# Altium schematic sheet Power Circuit.SchDoc
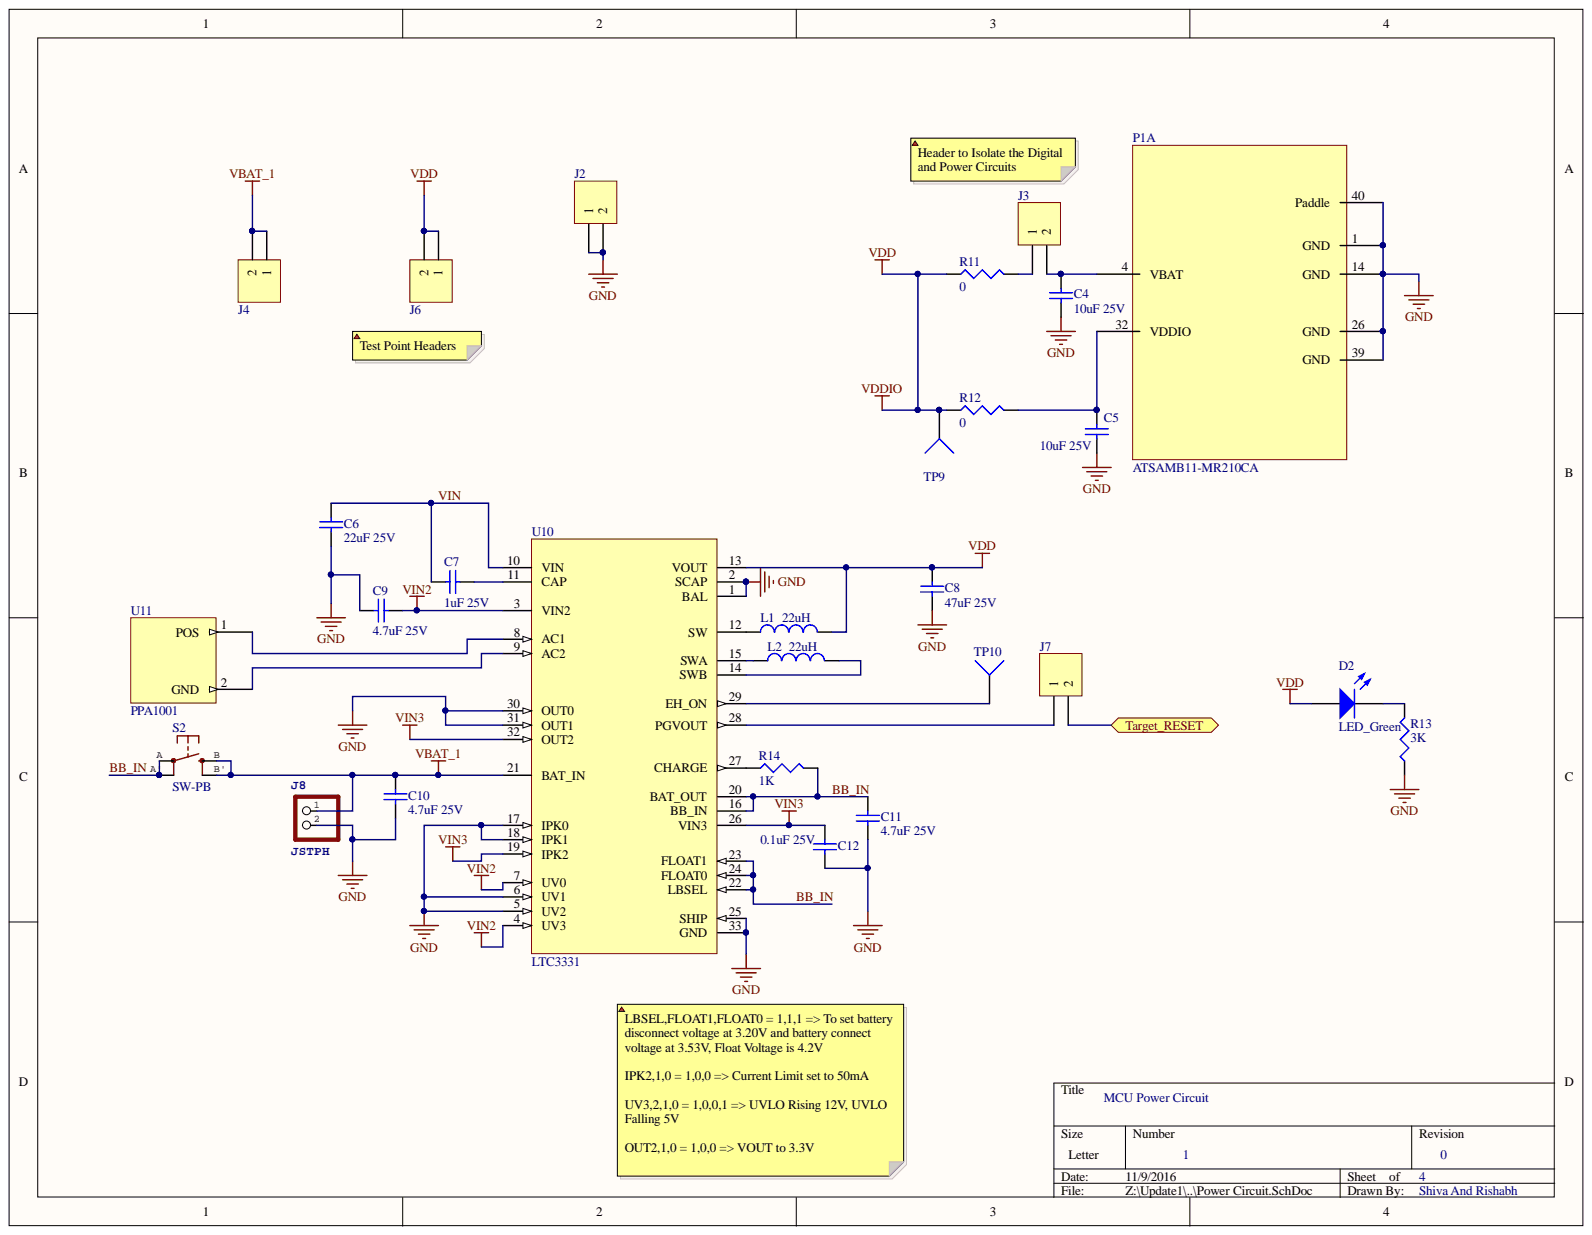
[diagram p0: Power Circuit.SchDoc]

## Parts
C4  value 10uF 25V  [Cap_1608[0603]]  (hidden comment: Cap10uF)
C5  value 10uF 25V  [Cap_1608[0603]]  (hidden comment: Cap10uF)
C6  value 22uF 25V  [Cap_1210]  (hidden comment: Cap22uF)
C7  value 1uF 25V  [Cap_1608[0603]]  (hidden comment: Cap1uF)
C8  value 47uF 25V  [Cap_1206]  (hidden comment: Cap47uF)
C9  value 4.7uF 25V  [Cap_1608[0603]]  (hidden comment: Cap4.7uF)
C10  value 4.7uF 25V  [Cap_1608[0603]]  (hidden comment: Cap4.7uF)
C11  value 4.7uF 25V  [Cap_1608[0603]]  (hidden comment: Cap4.7uF)
C12  value 0.1uF 25V  [Cap_1608[0603]]  (hidden comment: Cap0.1uF)
D2  LED_Green  [LED_0603]
J2  Header 2  [JMPR_HDR1X2]  (value not drawn)
J3  Header 2  [JMPR_HDR1X2]  (value not drawn)
J4  Header 2  [JMPR_HDR1X2]  (value not drawn)
J6  Header 2  [JMPR_HDR1X2]  (value not drawn)
J7  Header 2  [JMPR_HDR1X2]  (value not drawn)
J8  JSTPH  [JSTPH2]
L1  value 22uH  [INDUCTOR_1210_2]  (hidden comment: Ind22uH)
L2  value 22uH  [INDUCTOR_1210_2]  (hidden comment: Ind22uH)
P1  ATSAMB11-MR210CA  [SAMB11_1]  (part A)
R11  value 0  [Res_0603]  (hidden comment: Res0)
R12  value 0  [Res_0603]  (hidden comment: Res0)
R13  value 3K  [Res_0603]  (hidden comment: Res3K)
R14  value 1K  [Res_0603]  (hidden comment: Res1K)
S2  SW-PB  [SPST_PB]
TP9  Test Point  [TESTPOINT_1]
TP10  Test Point  [TESTPOINT_1]
U10  LTC3331  [LTC3331_QFN32]
U11  PPA1001  [Terminal Block]

## Ports
TARGET_RESET

## Nets
GND: C4.1 C5.1 C6.1 C8.1 C9.2 C10.2 C11.2 C12.2 J2.1 J2.2 J8.2 P1.1(GND) P1.14(GND) P1.26(GND) P1.39(GND) P1.40(Paddle) R13.1 U10.1(BAL) U10.2(SCAP) U10.5(UV2) U10.6(UV1) U10.17(IPK0) U10.18(IPK1) U10.25(SHIP) U10.30(OUT0) U10.31(OUT1) U10.33(GND)
VBAT_1: C10.1 J4.1 J4.2 J8.1 S2.B S2.B' U10.21(BAT_IN)
VDD: C8.2 D2.1 J6.1 J6.2 L1.2 R11.1 R12.1 TP9.1 U10.13(VOUT)
VIN2: C9.1 U10.3(VIN2) U10.4(UV3) U10.7(UV0)
VIN3: C12.1 U10.19(IPK2) U10.26(VIN3) U10.32(OUT2)
BB_IN: C11.1 R14.2 S2.A S2.A' U10.16(BB_IN) U10.20(BAT_OUT) U10.22(LBSEL) U10.23(FLOAT1) U10.24(FLOAT0)
VIN: C6.2 C7.1 U10.10(VIN)
NetC4_2: C4.2 J3.2 P1.4(VBAT)
NetC5_2: C5.2 P1.32(VDDIO) R12.2
NetC7_2: C7.2 U10.11(CAP)
NetD2_2: D2.2 R13.2
NetJ3_1: J3.1 R11.2
NetJ7_1: J7.1 U10.28(PGVOUT)
NetJ7_2: J7.2 ; port TARGET_RESET
NetL1_1: L1.1 U10.12(SW)
NetL2_1: L2.1 U10.15(SWA)
NetL2_2: L2.2 U10.14(SWB)
NetR14_1: R14.1 U10.27(CHARGE)
NetTP10_1: TP10.1 U10.29(EH_ON)
NetU10_8: U10.8(AC1) U11.1
NetU10_9: U10.9(AC2) U11.2

## Library data of the parts
C4 = Cap10uF: Capacitor (Semiconductor SIM Model); Manufacturer: Murata Electronics North America; ManufacturerPartNo: GRM188R61E106MA73D; Value: 10uF 25V; Component Kind: Standard; ComponentLink1Description: Datasheet; ComponentLink1URL: http://search.murata.co.jp/Ceramy/image/img/A01X/G101/ENG/GRM188R61E106MA73-01.pdf; Library Name: LR_Integrated_Library.IntLib; Supplier: Digikey; SupplierLink1URL: http://www.digikey.com/product-detail/en/murata-electronics-north-america/GRM319R71H104KA01D/490-1775-1-ND/587528; SupplierPartNo: 490-7202-1-ND
C5 = Cap10uF: Capacitor (Semiconductor SIM Model); Manufacturer: Murata Electronics North America; ManufacturerPartNo: GRM188R61E106MA73D; Component Kind: Standard; ComponentLink1Description: Datasheet; ComponentLink1URL: http://search.murata.co.jp/Ceramy/image/img/A01X/G101/ENG/GRM188R61E106MA73-01.pdf; Library Name: LR_Integrated_Library.IntLib; Supplier: Digikey; SupplierLink1URL: http://www.digikey.com/product-detail/en/murata-electronics-north-america/GRM319R71H104KA01D/490-1775-1-ND/587528; SupplierPartNo: 490-7202-1-ND
C6 = Cap22uF: Capacitor (Semiconductor SIM Model); Manufacturer: Murata Electronics North America; ManufacturerPartNo: GRM32ER61E226KE15K; Descripton: 22µF 25V Ceramic Capacitor X5R 1210; Component Kind: Standard; ComponentLink1Description: Datasheet; ComponentLink1URL: http://search.murata.co.jp/Ceramy/image/img/A01X/G101/ENG/GRM32ER61E226KE15-01.pdf; Library Name: LR_Integrated_Library.IntLib; Supplier: Digikey; SupplierLink1URL: http://www.digikey.com/product-detail/en/murata-electronics-north-america/GRM32ER61E226KE15K/490-6540-1-ND/3845737; SupplierPartNo: 490-6540-1-ND
C7 = Cap1uF: Capacitor (Semiconductor SIM Model); Manufacturer: Murata Electronics North America; ManufacturerPartNo: GRM188R61E105KA12D; Component Kind: Standard; ComponentLink1Description: Datasheet; ComponentLink1URL: http://search.murata.co.jp/Ceramy/image/img/A01X/G101/ENG/GRM188R61E105KA12-01.pdf; Library Name: LR_Integrated_Library.IntLib; Supplier: Digikey; SupplierLink1URL: http://www.digikey.com/product-detail/en/murata-electronics-north-america/GRM188R61E105KA12D/490-3897-1-ND/965939; SupplierPartNo: 490-3897-1-ND
C8 = Cap47uF: Capacitor (Semiconductor SIM Model); Manufacturer: TDK Corporation; ManufacturerPartNo: C3216X5R1E476M160AC; Component Kind: Standard; ComponentLink1Description: Datasheet; ComponentLink1URL: https://product.tdk.com/info/en/catalog/datasheets/mlcc_commercial_general_en.pdf; Library Name: LR_Integrated_Library.IntLib; Supplier: Digikey; SupplierLink1URL: http://www.digikey.com/product-detail/en/tdk-corporation/C3216X5R1E476M160AC/445-8047-1-ND/2792164; SupplierPartNo: 445-8047-1-ND
C9-C11 = Cap4.7uF: Capacitor (Semiconductor SIM Model); Manufacturer: Murata Electronics North America; ManufacturerPartNo: GRM188R61E475KE11D; Component Kind: Standard; ComponentLink1Description: Datasheet; ComponentLink1URL: http://search.murata.co.jp/Ceramy/image/img/A01X/G101/ENG/GRM188R61E475KE11-01.pdf; Library Name: LR_Integrated_Library.IntLib; Supplier: Digikey; SupplierLink1URL: http://www.digikey.com/product-detail/en/murata-electronics-north-america/GRM188R61E475KE11D/490-7203-1-ND/3900450; SupplierPartNo: 490-7203-1-ND
C12 = Cap0.1uF: Capacitor (Semiconductor SIM Model); Manufacturer: Murata Electronics North America; ManufacturerPartNo: GRM188R71E104KA01D; Value: 0.1uF 25V; Component Kind: Standard; ComponentLink1Description: Datasheet; ComponentLink1URL: http://search.murata.co.jp/Ceramy/image/img/A01X/G101/ENG/GRM188R71E104KA01-01.pdf; Library Name: LR_Integrated_Library.IntLib; Supplier: Digikey; SupplierLink1URL: http://www.digikey.com/product-detail/en/murata-electronics-north-america/GRM188R71E104KA01D/490-1524-1-ND/587865; SupplierPartNo: 490-1524-1-ND
D2 = LED_Green: Manufacturer: OSRAM; ManufacturerPartNo: LG L29K-F2J1-24-Z; Component Kind: Standard; ComponentLink1Description: Datasheet; ComponentLink1URL: http://www.osram-os.com/Graphics/XPic0/00167682_0.pdf; Description: LED_Green; Library Name: LR_Integrated_Library.IntLib; PackageDescription: SM LED; 2 Flat Leads; Quantity: 2; Supplier: Digikey; SupplierLink1URL: http://www.digikey.com/product-search/en?keywords=475-3118-1-ND; SupplierPartNo: 475-3118-1-ND
J2-J4 J6 J7 = Header 2: Header, 2-Pin; Manufacturer: Harwin Inc.; ManufacturerPartNo: M20-9990246; Component Kind: Standard; ComponentLink1Description: Drawing/Datasheet; ComponentLink1URL: https://cdn.harwin.com/pdfs/60page146.pdf; Library Name: LR_Integrated_Library.IntLib; Supplier: Digikey; SupplierLink1URL: http://www.digikey.com/product-search/en?keywords=952-2262-ND; SupplierPartNo: 952-2262-ND
J8 = JST_2PIN: JST 2-Pin Right-Angle Connector  PH-Series - 4UConnector: 17311  SH-Series - 4UConnector: 07278; ManufacturerPartNo: 17311; Component Kind: Standard; ComponentLink1Description: Drawing/Datasheet; ComponentLink1URL: https://cdn-shop.adafruit.com/datasheets/17311.pdf; Library Name: LR_Integrated_Library.IntLib; Manufacturer: 4UConnector; Supplier: Adafruit; SupplierLink1URL: https://www.adafruit.com/product/1769; SupplierPartNo: 1769
L1 L2 = Ind22uH: Inductor; Manufacturer: Taiyo Yuden; ManufacturerPartNo: CBC3225T220KR; Component Kind: Standard; ComponentLink1Description: Datasheet; ComponentLink1URL: http://www.yuden.co.jp/productdata/catalog/en/wound02_e.pdf; Library Name: LR_Integrated_Library.IntLib; Supplier: Digikey; SupplierLink1URL: http://www.digikey.com/product-detail/en/taiyo-yuden/CBC3225T220KR/587-3067-1-ND/2763492; SupplierPartNo: 587-3067-1-ND
P1 = ATSAMB11-MR210CA: Atmel BLE SoC Module; Component Kind: Standard; ComponentLink1Description: Datasheet; ComponentLink1URL: http://www.atmel.com/Images/Atmel-42498-SAMB11-MR210CA-MR510_Datasheet.pdf; Library Name: LR_Integrated_Library.IntLib; Manufacturer: Atmel; ManufacturerPartNo: ATSAMB11-MR210CA; Quantity: 1; Supplier: Digikey; SupplierLink1URL: http://www.digikey.com/product-detail/en/atmel/ATSAMB11-MR210CA/ATSAMB11-MR210CA-ND/5638846; SupplierPartNo: ATSAMB11-MR210CA-ND
R11 R12 = Res0: Resistor; Manufacturer: Yageo; ManufacturerPartNo: AC0603JR-070RL; Component Kind: Standard; ComponentLink1Description: Datasheet; ComponentLink1URL: http://www.yageo.com/NewPortal/yageodocoutput?fileName=/pdf/R-Chip/PYu-AC_51_RoHS_L_5.pdf; Library Name: LR_Integrated_Library.IntLib; Supplier: Digikey; SupplierLink1URL: http://www.digikey.com/product-detail/en/yageo/AC0603JR-070RL/311-0.0LECT-ND/2828149; SupplierPartNo: 311-0.0LECT-ND
R13 = Res3K: Resistor; Manufacturer: Yageo; ManufacturerPartNo: AC0603FR-073KL; Component Kind: Standard; ComponentLink1Description: Datasheet; ComponentLink1URL: http://www.yageo.com/documents/recent/PYu-AC_51_RoHS_L%205.pdf; Library Name: LR_Integrated_Library.IntLib; Supplier: Digikey; SupplierLink1URL: http://www.digikey.com/product-detail/en/yageo/AC0603FR-073KL/YAG3607CT-ND/6006456; SupplierPartNo: YAG3607CT-ND
R14 = Res1K: Resistor; Manufacturer: Yageo; ManufacturerPartNo: AC0603FR-071KL; Component Kind: Standard; ComponentLink1Description: Datasheet; ComponentLink1URL: http://www.yageo.com/NewPortal/yageodocoutput?fileName=/pdf/R-Chip/PYu-AC_51_RoHS_L_5.pdf; Library Name: LR_Integrated_Library.IntLib; Supplier: Digikey; SupplierLink1URL: http://www.digikey.com/product-search/en?keywords=311-1KLDCT-ND; SupplierPartNo: 311-1KLDCT-ND
S2 = SW-PB: Tactile Switch SPST-NO Top Actuated Surface Mount; Manufacturer: C&K Components; ManufacturerPartNo: KMR211GLFS; Component Kind: Standard; ComponentLink1Description: Drawing/Datasheet; ComponentLink1URL: http://www.ckswitches.com/media/1479/kmr2.pdf; Library Name: LR_Integrated_Library.IntLib; Supplier: Digikey; SupplierLink1URL: http://www.digikey.com/product-search/en?keywords=401-1426-1-ND%20; SupplierPartNo: 401-1426-1-ND
U10 = LTC3331: Nanopower Buck-Boost  DC/DC with Energy Harvesting  Battery Charger; Manufacturer: Linear Technology; ManufacturerPartNo: LTC3331EUH#PBF; Component Kind: Standard; ComponentLink1Description: Datasheet; ComponentLink1URL: http://www.linear.com/docs/44969; Library Name: LR_Integrated_Library.IntLib; Supplier: Digikey; SupplierLink1URL: http://www.digikey.com/product-detail/en/linear-technology/LTC3331EUH-PBF/LTC3331EUH-PBF-ND/4895694; SupplierPartNo: LTC3331EUH#PBF-ND
U11 = PPA1001: Piezoelectric Film; Manufacturer: MIDE; Component Kind: Standard; ComponentLink1Description: Datasheet; ComponentLink1URL: http://www.mouser.com/ds/2/606/ppa-piezo-product-datasheet-844547.pdf; Library Name: LR_Integrated_Library.IntLib; ManufacturerPartNo: PPA-1001; MouserPartNo: 509-PPA-1001; Quantity: 1; Supplier: Mouser; SupplierLink: http://www.mouser.com/ProductDetail/Mide/PPA-1001/?qs=Z8kPBbqMm1MdJKag0z4egA%3D%3D
TP9 TP10 = Test Point: Component Kind: Standard; Description: Test Point; LatestRevisionDate: 17-Jul-2002; LatestRevisionNote: Re-released for DXP Platform.; Library Name: LR_Integrated_Library.IntLib; Publisher: Altium Limited
